# Altium schematic sheet 2_INTERFACES_EXTERNAS.SchDoc
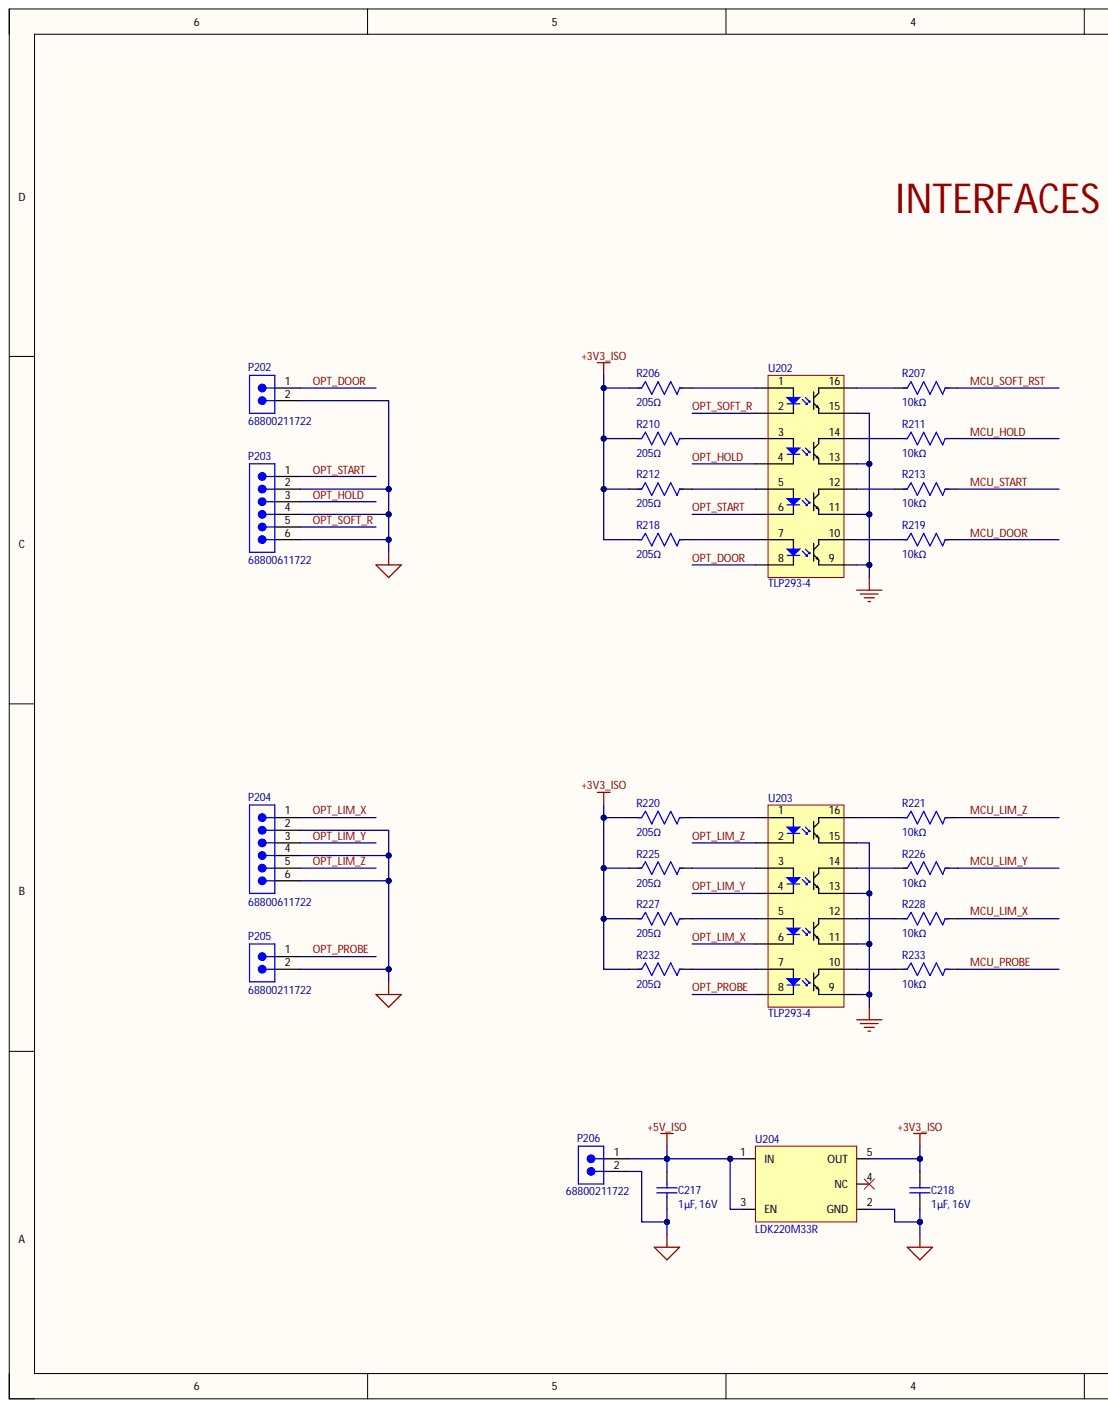
[diagram p0: 2_INTERFACES_EXTERNAS.SchDoc, left]
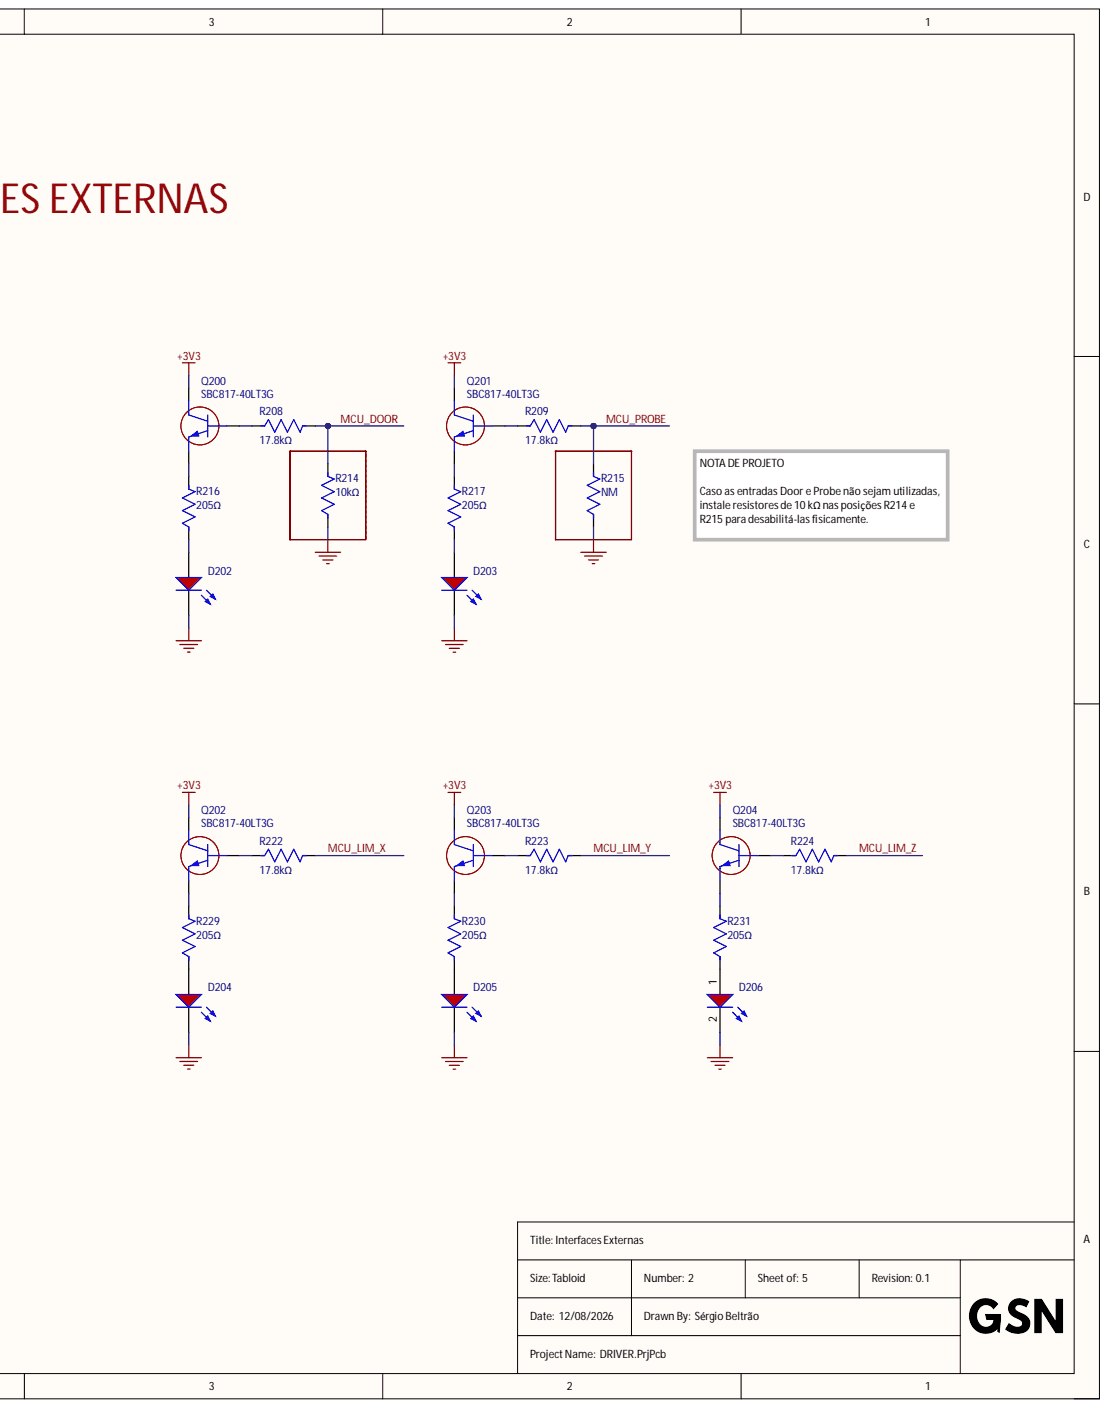
[diagram p1: 2_INTERFACES_EXTERNAS.SchDoc, right]

## Parts
C217  1μF, 16V  Rated Voltage (DC): 16V  [WCAP-CSGP_0603_L1.6W0.8H0.8_Wave]
C218  1μF, 16V  Rated Voltage (DC): 16V  [WCAP-CSGP_0603_L1.6W0.8H0.8_Wave]
D202  Red, 2V, 140deg  [WL-SMCW_0603_150060xx75003]  (value not drawn)
D203  Red, 2V, 140deg  [WL-SMCW_0603_150060xx75003]  (value not drawn)
D204  Red, 2V, 140deg  [WL-SMCW_0603_150060xx75003]  (value not drawn)
D205  Red, 2V, 140deg  [WL-SMCW_0603_150060xx75003]  (value not drawn)
D206  Red, 2V, 140deg  [WL-SMCW_0603_150060xx75003]  (value not drawn)
P202  68800211722  [68800211722]
P203  68800611722  [68800611722]
P204  68800611722  [68800611722]
P205  68800211722  [68800211722]
P206  68800211722  [68800211722]
Q200  SBC817-40LT3G  [SOT-23-3]
Q201  SBC817-40LT3G  [SOT-23-3]
Q202  SBC817-40LT3G  [SOT-23-3]
Q203  SBC817-40LT3G  [SOT-23-3]
Q204  SBC817-40LT3G  [SOT-23-3]
R206  205Ω  [0603-WAVE]
R207  10kΩ  [0603-WAVE]
R208  17.8kΩ  [0603-WAVE]
R209  17.8kΩ  [0603-WAVE]
R210  205Ω  [0603-WAVE]
R211  10kΩ  [0603-WAVE]
R212  205Ω  [0603-WAVE]
R213  10kΩ  [0603-WAVE]
R214  10kΩ  [0603-WAVE]
R215  NM  [0603-WAVE]
R216  205Ω  [0603-WAVE]
R217  205Ω  [0603-WAVE]
R218  205Ω  [0603-WAVE]
R219  10kΩ  [0603-WAVE]
R220  205Ω  [0603-WAVE]
R221  10kΩ  [0603-WAVE]
R222  17.8kΩ  [0603-WAVE]
R223  17.8kΩ  [0603-WAVE]
R224  17.8kΩ  [0603-WAVE]
R225  205Ω  [0603-WAVE]
R226  10kΩ  [0603-WAVE]
R227  205Ω  [0603-WAVE]
R228  10kΩ  [0603-WAVE]
R229  205Ω  [0603-WAVE]
R230  205Ω  [0603-WAVE]
R231  205Ω  [0603-WAVE]
R232  205Ω  [0603-WAVE]
R233  10kΩ  [0603-WAVE]
U202  TLP293-4  [SO-16]
U203  TLP293-4  [SO-16]
U204  LDK220M33R  [SOT-23-5]

## Nets
+3V3: Q200.3 Q201.3 Q202.3 Q203.3 Q204.3
+3V3_ISO: C218.2 R206.1 R210.1 R212.1 R218.1 R220.1 R225.1 R227.1 R232.1 U204.5(OUT)
+5V_ISO: C217.2 P206.1 U204.1(IN) U204.3(EN)
GND: D202.2 D203.2 D204.2 D205.2 D206.2 R214.1 R215.1 U202.9 U202.11 U202.13 U202.15 U203.9 U203.11 U203.13 U203.15
GND_ISO: C217.1 C218.1 P202.2 P203.2 P203.4 P203.6 P204.2 P204.4 P204.6 P205.2 P206.2 U204.2(GND)
MCU_DOOR: R208.2 R214.2 R219.2
MCU_HOLD: R211.2
MCU_LIM_X: R222.2 R228.2
MCU_LIM_Y: R223.2 R226.2
MCU_LIM_Z: R221.2 R224.2
MCU_PROBE: R209.2 R215.2 R233.2
MCU_SOFT_RST: R207.2
MCU_START: R213.2
OPT_DOOR: P202.1 U202.8
OPT_HOLD: P203.3 U202.4
OPT_LIM_X: P204.1 U203.6
OPT_LIM_Y: P204.3 U203.4
OPT_LIM_Z: P204.5 U203.2
OPT_PROBE: P205.1 U203.8
OPT_SOFT_R: P203.5 U202.2
OPT_START: P203.1 U202.6
NetD202_1: D202.1 R216.1
NetD203_1: D203.1 R217.1
NetD204_1: D204.1 R229.1
NetD205_1: D205.1 R230.1
NetD206_1: D206.1 R231.1
NetQ200_1: Q200.1 R208.1
NetQ200_2: Q200.2 R216.2
NetQ201_1: Q201.1 R209.1
NetQ201_2: Q201.2 R217.2
NetQ202_1: Q202.1 R222.1
NetQ202_2: Q202.2 R229.2
NetQ203_1: Q203.1 R223.1
NetQ203_2: Q203.2 R230.2
NetQ204_1: Q204.1 R224.1
NetQ204_2: Q204.2 R231.2
NetR206_2: R206.2 U202.1
NetR207_1: R207.1 U202.16
NetR210_2: R210.2 U202.3
NetR211_1: R211.1 U202.14
NetR212_2: R212.2 U202.5
NetR213_1: R213.1 U202.12
NetR218_2: R218.2 U202.7
NetR219_1: R219.1 U202.10
NetR220_2: R220.2 U203.1
NetR221_1: R221.1 U203.16
NetR225_2: R225.2 U203.3
NetR226_1: R226.1 U203.14
NetR227_2: R227.2 U203.5
NetR228_1: R228.1 U203.12
NetR232_2: R232.2 U203.7
NetR233_1: R233.1 U203.10

Not connected: U204 4(NC)

## Library data of the parts
C217 C218 = 885012206052: WCAP-CSGP Multilayer Ceramic Chip Capacitor, General Purpose, size 0603, 1μF, 16V; Manufacturer: Wurth Elektronik; Capacitance: 1uF; Capacitance Tolerance: +/-10%; Case/Size Code: 0603; Ceramic Type: X7R; Database Table Name: Capacitors; Dissipation Factor: 10%; Insulation Resistance: 0.1Gohm; Technical Reference: X7R0603105K016DFCT10000; Category: Multilayer Ceramic Chip Capacitors; Component Kind: Standard; ComponentLink1Description: Website Link; ComponentLink1URL: https://www.we-online.com/redexpert/article/885012206052?ad; ComponentLink2Description: Datasheet Link; ComponentLink2URL: https://www.we-online.com/redexpert/spec/885012206052?ad; Height: 0.8mm; Length: 1.6mm; Library Name: WE - Passive Components.DbLib; Manufacturer Part Number: 885012206052; Match Code: WCAP-CSGP; Mount: Surface Mount; Operating Temperature Max: 125degC; Operating Temperature Min: -55degC; Packaging: Tape and Reel; Simulation: 0603_885012206052_1uF; Width: 0.8mm
D202-D206 = 150060RS75003: WL-SMCW Mono-color Chip LED Waterclear, SMT, size 0603, Red, 2V, 140°; Manufacturer: Wurth Elektronik; Case/Size Code: 0603; Chip Technology: AlInGaP; Database Table Name: LEDs; Dominant Wavelength: 625nm; Luminous Intensity: 530mcd; Peak Wavelength: 630nm; Category: Signal LEDs; Component Kind: Standard; ComponentLink1Description: Website Link; ComponentLink1URL: https://www.we-online.com/redexpert/article/150060RS75003?ad; ComponentLink2Description: Datasheet Link; ComponentLink2URL: https://www.we-online.com/redexpert/spec/150060RS75003?ad; Emitting Color: Red; Forward Voltage: 2V; Height: 0.7mm; Length: 1.6mm; Library Name: WE - Optoelectronic Components.DbLib; Manufacturer Part Number: 150060RS75003; Match Code: WL-SMCW; Mount: Surface Mount; Operating Temperature Max: 100degC; Operating Temperature Min: -40degC; Packaging: Tape and Reel; Simulation: 0603_150060RS75003; Viewing Angle: 140deg; Width: 0.8mm
P202 P205 P206 = 68800211722: WR-WTB “J” Type THT Male Horizontal Shrouded Header, pitch 2.5 mm, 2 pins; Manufacturer: Wurth Elektronik; Contact Resistance: 20 mohm; Database Table Name: Connectors; Rated Current: 3 A; Withstanding Voltage: 800 V(AC); Working Voltage: 250 V(AC); Category: Wire-to-Board Connector; Component Kind: Standard; ComponentLink1Description: Website Link; ComponentLink1URL: https://www.we-online.com/redexpert/article/68800211722?ad; ComponentLink2Description: Datasheet Link; ComponentLink2URL: https://www.we-online.com/redexpert/spec/68800211722?ad; Gender: Male; Height: 4.2 mm; Lead Pitch: 2.5 mm; Length: 7.5 mm; Library Name: WE - Electromechanical Components.DbLib; Manufacturer Part Number: 68800211722; Match Code: WR-WTB; Mount: Through Hole; Number of Pins: 2; Number of Rows: 1; Operating Temperature Max: 85degC; Operating Temperature Min: -25degC; Orientation: Horizontal; Packaging: Bag; Width: 8.3 mm
P203 P204 = 68800611722: WR-WTB “J” Type THT Male Horizontal Shrouded Header, pitch 2.5 mm, 6 pins; Manufacturer: Wurth Elektronik; Contact Resistance: 20 mohm; Database Table Name: Connectors; Rated Current: 3 A; Withstanding Voltage: 800 V(AC); Working Voltage: 250 V(AC); Category: Wire-to-Board Connector; Component Kind: Standard; ComponentLink1Description: Website Link; ComponentLink1URL: https://www.we-online.com/redexpert/article/68800611722?ad; ComponentLink2Description: Datasheet Link; ComponentLink2URL: https://www.we-online.com/redexpert/spec/68800611722?ad; Gender: Male; Height: 4.2 mm; Lead Pitch: 2.5 mm; Length: 17.5 mm; Library Name: WE - Electromechanical Components.DbLib; Manufacturer Part Number: 68800611722; Match Code: WR-WTB; Mount: Through Hole; Number of Pins: 6; Number of Rows: 1; Operating Temperature Max: 85degC; Operating Temperature Min: -25degC; Orientation: Horizontal; Packaging: Bag; Width: 8.3 mm
Q200-Q204 = SBC817-40LT3G: TRANS NPN 45V 0.5A SOT23-3; Manufacturer: onsemi; Manufacturer Lifecycle Status: ACTIVE; Clock Frequency: 100MHz; Collector Base Voltage (VCBO): 50V; Continuous Collector Current: 500mA; Element Configuration: Single; Emitter Base Voltage (VEBO): 5V; hFE Min: 250; Max Power Dissipation: 300mW; Number of Elements: 1; Case/Package: SOT-23-3; Category: BJTs; China RoHS: Compliant; Collector Emitter Breakdown Voltage: 45V; Collector Emitter Voltage (VCEO): 45V; Component Kind: Standard; ComponentLink1Description: https://datasheet.octopart.com:443/SBC817-40LT3G-onsemi-datasheet-175914671.pdf?src-supplier=IHS; ComponentLink1URL: https://datasheet.octopart.com:443/SBC817-40LT3G-onsemi-datasheet-175914671.pdf?src-supplier=IHS; ComponentLink2Description: https://datasheet.octopart.com:443/SBC817-40LT3G-ON-Semiconductor-datasheet-11547464.pdf?src-supplier=Upverter; ComponentLink2URL: https://datasheet.octopart.com:443/SBC817-40LT3G-ON-Semiconductor-datasheet-11547464.pdf?src-supplier=Upverter; ComponentLink3Description: https://datasheet.octopart.com:443/SBC817-40LT3G-ON-Semiconductor-datasheet-78758879.pdf?src-supplier=Master+Electronics; ComponentLink3URL: https://datasheet.octopart.com:443/SBC817-40LT3G-ON-Semiconductor-datasheet-78758879.pdf?src-supplier=Master+Electronics; ComponentLink4Description: https://datasheet.octopart.com:443/SBC817-40LT3G-onsemi-datasheet-189132499.pdf?src-supplier=Master+Electronics; ComponentLink4URL: https://datasheet.octopart.com:443/SBC817-40LT3G-onsemi-datasheet-189132499.pdf?src-supplier=Master+Electronics; ComponentLink5Description: https://datasheet.octopart.com:443/SBC817-40LT3G-ON-Semiconductor-datasheet-8110464.pdf?src-supplier=onsemi; ComponentLink5URL: https://datasheet.octopart.com:443/SBC817-40LT3G-ON-Semiconductor-datasheet-8110464.pdf?src-supplier=onsemi; ComponentLink6Description: https://datasheet.octopart.com:443/SBC817-40LT3G-ON-Semiconductor-datasheet-6400296.pdf?src-supplier=onsemi; ComponentLink6URL: https://datasheet.octopart.com:443/SBC817-40LT3G-ON-Semiconductor-datasheet-6400296.pdf?src-supplier=onsemi; ComponentLink7Description: https://datasheet.octopart.com:443/SBC817-40LT3G-onsemi-datasheet-133521192.pdf?src-supplier=TME; ComponentLink7URL: https://datasheet.octopart.com:443/SBC817-40LT3G-onsemi-datasheet-133521192.pdf?src-supplier=TME; ComponentLink8Description: http://sigma.octopart.com:80/15540786/rohs_certificate/ON-Semiconductor-SBC817-40LT3G.pdf?src-supplier=Compliance+Statements; ComponentLink8URL: http://sigma.octopart.com:80/15540786/rohs_certificate/ON-Semiconductor-SBC817-40LT3G.pdf?src-supplier=Compliance+Statements; ComponentLink9Description: http://sigma.octopart.com:80/15684525/materials_sheet/ON-Semiconductor-SBC817-40LT3G.csv?src-supplier=Compliance+Statements; ComponentLink9URL: http://sigma.octopart.com:80/15684525/materials_sheet/ON-Semiconductor-SBC817-40LT3G.csv?src-supplier=Compliance+Statements; ComponentLink10Description: http://sigma.octopart.com:80/150631289/rohs_statement/ON-Semiconductor-SBC817-40LT3G.pdf?src-supplier=Compliance+Statements; ComponentLink10URL: http://sigma.octopart.com:80/150631289/rohs_statement/ON-Semiconductor-SBC817-40LT3G.pdf?src-supplier=Compliance+Statements; ComponentLink11Description: http://sigma.octopart.com:80/reach_statement/ON-Semiconductor-company-79.pdf?src-supplier=Compliance+Statements; ComponentLink11URL: http://sigma.octopart.com:80/reach_statement/ON-Semiconductor-company-79.pdf?src-supplier=Compliance+Statements; ComponentLink12Description: https://octopart.com:443/opatz8j6/c1?t=cEC30QwZsyb0x-FMX1jhecc8cj0lODbyQVaJL5I4EOudI-i4uiyo8QJe7x8WGpb_UfldyhXdI6wTsOpeJ5HWz7Kzleo1EiYpUhSwBawIenFlUCJsMQESd9nlIcVrbvWrabnb6HpXCtvxDkswRDKZDOG3MBWymJDy-mDPZ7u5UlptsX8-ONvWANeBowi-w7nz9iZWLyjqVoM_QTKEQgTILpDidA8CXVyMync_ukwLaadxciX7hbgDafM4N20bPQPEg7z2Sm1KwG15cEibpJqtQ1hKuQpn-edZc_o2ddvdnsTvT3gddPA_45tVxaNa9XWOJj00WFdTbgFSlU6TTFEybWVRRlK52823bHwN5uVZ-7M&src-supplier=Compliance+Statements; ComponentLink12URL: https://octopart.com:443/opatz8j6/c1?t=cEC30QwZsyb0x-FMX1jhecc8cj0lODbyQVaJL5I4EOudI-i4uiyo8QJe7x8WGpb_UfldyhXdI6wTsOpeJ5HWz7Kzleo1EiYpUhSwBawIenFlUCJsMQESd9nlIcVrbvWrabnb6HpXCtvxDkswRDKZDOG3MBWymJDy-mDPZ7u5UlptsX8-ONvWANeBowi-w7nz9iZWLyjqVoM_QTKEQgTILpDidA8CXVyMync_ukwLaadxciX7hbgDafM4N20bPQPEg7z2Sm1KwG15cEibpJqtQ1hKuQpn-edZc_o2ddvdnsTvT3gddPA_45tVxaNa9XWOJj00WFdTbgFSlU6TTFEybWVRRlK52823bHwN5uVZ-7M&src-supplier=Compliance+Statements; ComponentLink13Description: https://octopart.com:443/opatz8j6/c1?t=zgMoQUE-FAGizIL2uNADbP3CQfJXduVKNLOt0cT-C7XNOnl5EOgq1b4UVzb0SZjomH3a3CKF513mPEsnVuWFTldNModuoiOAROM-U7UMjU1TiA4JeYDoVNfia-psQDQPr2NtqLaOU9Ju06PrsXVFYjz3NjgsSVJa-5X9CcWQ1HPxPGGUjhKccWy9QJVPTcefUdorkFOZvdb3XFmpP_42jgzyR9ZUQAURqjwANTg96Z5ittV_NTML0IEyFPhh0jLh0am3ICJpb8VA9ph6AsqLc9j4fhdilqUiQTpG4UGLijUcgX0mpfEUR-9G0JRIiKjoFhJPWB3BEKd5JcwsK41biiNJ-0hjTcgtgsqlWYFFTPLS&src-supplier=Compliance+Statements; ComponentLink13URL: https://octopart.com:443/opatz8j6/c1?t=zgMoQUE-FAGizIL2uNADbP3CQfJXduVKNLOt0cT-C7XNOnl5EOgq1b4UVzb0SZjomH3a3CKF513mPEsnVuWFTldNModuoiOAROM-U7UMjU1TiA4JeYDoVNfia-psQDQPr2NtqLaOU9Ju06PrsXVFYjz3NjgsSVJa-5X9CcWQ1HPxPGGUjhKccWy9QJVPTcefUdorkFOZvdb3XFmpP_42jgzyR9ZUQAURqjwANTg96Z5ittV_NTML0IEyFPhh0jLh0am3ICJpb8VA9ph6AsqLc9j4fhdilqUiQTpG4UGLijUcgX0mpfEUR-9G0JRIiKjoFhJPWB3BEKd5JcwsK41biiNJ-0hjTcgtgsqlWYFFTPLS&src-supplier=Compliance+Statements; Country of Origin: Mainland China; Export Control Classification Number (ECCN) Code: EAR99; Frequency: 100MHz; Halogen Free: Halogen Free; Introduction Date: 1991-03-01; Lead Free: Lead Free; Library Name: Transistors.SchLib; Lifecycle Status: Production; Manufacturer Part Number: SBC817-40LT3G; Max Breakdown Voltage: 45V; Max Collector Current: 500mA; Max Operating Temperature: 150°C; Min Operating Temperature: -55°C; Number of Terminals: 3; Packaging: Tape and Reel; Pins: 3; Polarity: NPN; Power Dissipation: 300mW; Pricing: 1=0.24, 10=0.146, 100=0.0904, 500=0.06616, 1000=0.05829, 2000=0.05165, 5000=0.04443 (USD); Radiation Hardening: No; REACH SVHC: Yes; RoHS: Compliant; Schedule B: 8541210080, 8541210080|8541210080, 8541210080|8541210080|8541210080, 8541210080|8541210080|8541210080|8541210080; Stock: 144045; Supplier: DigiKey; Supplier Part Number: SBC817-40LT3GOSCT-ND; Transition Frequency: 100MHz
R206 R210 R212 R216-R218 R220 R225 R227 R229-R232 = RC0603FR-07205RL: RES 205 OHM 1% 1/10W 0603; Manufacturer: Yageo Group; Max Power Dissipation: 100mW; Temperature Coefficient: ±200ppm/°C; Voltage Rating: 75V; Voltage Rating (AC): 50V; Case Code (Imperial): 0603; Case Code (Metric): 1608; Case/Package: 0603; Category: Chip SMD Resistors; China RoHS: Non-Compliant; Component Kind: Standard; Component Link Description: https://www.yageogroup.com/content/datasheet/asset/file/PYU-RC_GROUP_51_ROHS_L; Component Link URL: https://www.yageogroup.com/content/datasheet/asset/file/PYU-RC_GROUP_51_ROHS_L; Composition: Thick Film; Contact Plating: Tin; Datasheet: https://www.yageogroup.com/content/datasheet/asset/file/PYU-RC_GROUP_51_ROHS_L; Depth: 800µm; Features: Moisture Resistant; Height: 450µm; Lead Free: Lead Free; Length: 1.6mm; Library Name: Resistors-0603-E96-Yageo.SchLib; Lifecycle Status: Production; Manufacturer Part Number: RC0603FR-07205RL; Max Operating Temperature: 155°C; Military Standard: Not; Min Operating Temperature: -55°C; Mounting Technology: Surface Mount; Number of Terminals: 2; Number of Terminations: 2; Packaging: Tape & Reel; Power Dissipation: 100mW; Power Rating: 100mW; Radiation Hardening: No; REACH SVHC: Yes; RoHS: Compliant; Supplier: DigiKey; Supplier Part Number: 311-205HRCT-ND; Termination: SMD/SMT; Tolerance: 1%; Value: 205Ω; Voltage Rating (DC): 50V; Weight: 2.012816mg; Width: 800µm; Working Voltage: 75V
R207 R211 R213-R215 R219 R221 R226 R228 R233 = RC0603FR-0710KL: RES 10K OHM 1% 1/10W 0603; Manufacturer: Yageo Group; Max Power Dissipation: 100mW; Temperature Coefficient: ±200ppm/°C; Voltage Rating: 75V; Voltage Rating (AC): 50V; Case Code (Imperial): 0603; Case Code (Metric): 1608; Case/Package: 0603; Category: Chip SMD Resistors; China RoHS: Non-Compliant; Component Kind: Standard; Component Link Description: https://www.yageogroup.com/content/datasheet/asset/file/PYU-RC_GROUP_51_ROHS_L; Component Link URL: https://www.yageogroup.com/content/datasheet/asset/file/PYU-RC_GROUP_51_ROHS_L; Composition: Thick Film; Contact Plating: Tin; Datasheet: https://www.yageogroup.com/content/datasheet/asset/file/PYU-RC_GROUP_51_ROHS_L; Depth: 800µm; Features: Moisture Resistant; Height: 450µm; Lead Free: Lead Free; Length: 1.6mm; Library Name: Resistors-0603-E96-Yageo.SchLib; Lifecycle Status: Production; Manufacturer Part Number: RC0603FR-0710KL; Max Operating Temperature: 155°C; Military Standard: Not; Min Operating Temperature: -55°C; Mounting Technology: Surface Mount; Number of Terminals: 2; Number of Terminations: 2; Packaging: Tape & Reel; Power Dissipation: 100mW; Power Rating: 100mW; Radiation Hardening: No; REACH SVHC: Yes; RoHS: Compliant; Supplier: DigiKey; Supplier Part Number: 311-10.0KHRCT-ND; Termination: SMD/SMT; Tolerance: 1%; Voltage Rating (DC): 50V; Weight: 2.012816mg; Width: 800µm; Working Voltage: 75V
  R207 R211 R213 R214 R219 R221 R226 R228 R233: Value: 10kΩ
  R215: Value: NM
R208 R209 R222-R224 = RC0603FR-0717K8L: RES 17.8K OHM 1% 1/10W 0603; Manufacturer: Yageo Group; Max Power Dissipation: 100mW; Temperature Coefficient: ±200ppm/°C; Voltage Rating: 75V; Voltage Rating (AC): 50V; Case Code (Imperial): 0603; Case Code (Metric): 1608; Case/Package: 0603; Category: Chip SMD Resistors; China RoHS: Non-Compliant; Component Kind: Standard; Component Link Description: https://www.yageogroup.com/content/datasheet/asset/file/PYU-RC_GROUP_51_ROHS_L; Component Link URL: https://www.yageogroup.com/content/datasheet/asset/file/PYU-RC_GROUP_51_ROHS_L; Composition: Thick Film; Contact Plating: Tin; Datasheet: https://www.yageogroup.com/content/datasheet/asset/file/PYU-RC_GROUP_51_ROHS_L; Depth: 800µm; Features: Moisture Resistant; Height: 450µm; Lead Free: Lead Free; Length: 1.6mm; Library Name: Resistors-0603-E96-Yageo.SchLib; Lifecycle Status: Production; Manufacturer Part Number: RC0603FR-0717K8L; Max Operating Temperature: 155°C; Military Standard: Not; Min Operating Temperature: -55°C; Mounting Technology: Surface Mount; Number of Terminals: 2; Number of Terminations: 2; Packaging: Tape & Reel; Power Dissipation: 100mW; Power Rating: 100mW; Radiation Hardening: No; REACH SVHC: Yes; RoHS: Compliant; Supplier: DigiKey; Supplier Part Number: 311-17.8KHRCT-ND; Termination: SMD/SMT; Tolerance: 1%; Value: 17.8kΩ; Voltage Rating (DC): 50V; Weight: 2.012816mg; Width: 800µm; Working Voltage: 75V
U202 U203 = TLP293-4: OPTOISO 3.75KV 4CH TRANS 16-SO; Manufacturer: Toshiba; Manufacturer Part Number: TLP293-4(TP,E; Collector Emitter Breakdown Voltage: 80V; Collector Emitter Saturation Voltage: 300mV; Current Transfer Ratio: 50%; Dark Current: 80nA; Forward Current: 50mA; Forward Voltage: 1.4V; Max Junction Temperature (Tj): 125°C; Max Power Dissipation: 100mW; Number of Channels: 4; Turn-Off Delay Time: 3µs; Case/Package: SOIC; Category: Optocouplers; Collector Emitter Voltage (VCEO): 300mV; Component Kind: Standard; ComponentLink1Description: https://datasheet.octopart.com:443/TLP293-4%28TP%2CE-Toshiba-datasheet-165584699.pdf?src-supplier=IHS; ComponentLink1URL: https://datasheet.octopart.com:443/TLP293-4%28TP%2CE-Toshiba-datasheet-165584699.pdf?src-supplier=IHS; ComponentLink2Description: https://datasheet.octopart.com:443/TLP293-4%28TP%2CE-Toshiba-datasheet-62096132.pdf?src-supplier=Upverter; ComponentLink2URL: https://datasheet.octopart.com:443/TLP293-4%28TP%2CE-Toshiba-datasheet-62096132.pdf?src-supplier=Upverter; Height: 2.3mm; Isolation Voltage: 3.75kV; Lifecycle Status: Production; Max Operating Temperature: 125°C; Max Output Voltage: 80V; Min Operating Temperature: -55°C; Mounting Technology: Surface Mount; Number of Elements: 4; Output Current per Channel: 50mA; Packaging: Tape & Reel (TR); Pins: 16; Pricing: 1=1.54, 10=1.093, 100=0.8233, 500=0.704, 1000=0.66505 (USD); REACH SVHC: Yes; RoHS: Compliant; Schedule B: 8541408000, 8541408000|8541408000|8541408000|8541408000|8541408000; Stock: 42408; Supplier: DigiKey; Supplier Part Number: TLP293-4(TPECT-ND; Turn-On Delay Time: 3µs; Library Name: Optocouplers.SchLib
U204 = LDK220M33R: IC REG LINEAR 3.3V 200MA SOT23-5; Manufacturer: STMicroelectronics; Accuracy: 2%; Dropout Voltage: 200mV; Max Junction Temperature (Tj): 125°C; Max Power Dissipation: 500mW; Max Supply Voltage: 13.2V; Min Input Voltage: 4.3V; Number of Channels: 1; Output Type: Fixed; Polarity: Positive; Quiescent Current: 60µA; Voltage Tolerance: 3%; Case/Package: LSSOP; Category: LDO Voltage Regulators; China RoHS: Compliant; Component Kind: Standard; ComponentLink1Description: https://datasheet.octopart.com:443/LDK220M33R-STMicroelectronics-datasheet-164563982.pdf?src-supplier=IHS; ComponentLink1URL: https://datasheet.octopart.com:443/LDK220M33R-STMicroelectronics-datasheet-164563982.pdf?src-supplier=IHS; ComponentLink2Description: https://datasheet.octopart.com:443/LDK220M33R-STMicroelectronics-datasheet-44506895.pdf?src-supplier=element14+APAC; ComponentLink2URL: https://datasheet.octopart.com:443/LDK220M33R-STMicroelectronics-datasheet-44506895.pdf?src-supplier=element14+APAC; ComponentLink3Description: https://datasheet.octopart.com:443/LDK220M33R-STMicroelectronics-datasheet-24910216.pdf?src-supplier=Future+Electronics; ComponentLink3URL: https://datasheet.octopart.com:443/LDK220M33R-STMicroelectronics-datasheet-24910216.pdf?src-supplier=Future+Electronics; ComponentLink4Description: https://datasheet.octopart.com:443/LDK220M33R-STMicroelectronics-datasheet-36991664.pdf?src-supplier=Newark; ComponentLink4URL: https://datasheet.octopart.com:443/LDK220M33R-STMicroelectronics-datasheet-36991664.pdf?src-supplier=Newark; ComponentLink5Description: https://datasheet.octopart.com:443/LDK220M33R-STMicroelectronics-datasheet-101746762.pdf?src-supplier=Augswan; ComponentLink5URL: https://datasheet.octopart.com:443/LDK220M33R-STMicroelectronics-datasheet-101746762.pdf?src-supplier=Augswan; Contact Plating: Tin; Country of Origin: Mainland China; Export Control Classification Number (ECCN) Code: EAR99; Harmonized Tariff Schedule (HTS) Code: 8542.39.00.60; Height: 1mm; Height - Seated (Max): 1.45mm; Introduction Date: 2014-03-19; Lead Free: Lead Free; Length: 2.95mm; Library Name: Voltage-Regulators.SchLib; Lifecycle Status: Production; Manufacturer Part Number: LDK220M33R; Max Operating Temperature: 125°C; Max Output current: 200mA; Min Operating Temperature: -40°C; Mounting Technology: Surface Mount; Nominal Output Voltage: 3.3V; Number of Circuits: 1; Number of Outputs: 1; Number of Regulators: 1; Number of Terminals: 5; Output Current: 200mA; Output Voltage: 3.3V; Packaging: Cut Tape; Pins: 5; Pricing: 1=0.39, 10=0.268, 25=0.2388, 100=0.2061, 250=0.1906, 500=0.18124, 1000=0.17353 (USD); Radiation Hardening: No; REACH SVHC: Yes; RoHS: Compliant; Schedule B: 8542390000, 8542390000|8542390000|8542390000|8542390000|8542390000; Stock: 13215; Supplier: DigiKey; Supplier Part Number: 497-14824-1-ND; Terminal Pitch: 950µm; Weight: 29.993795mg; Width: 1.6mm
